# Altium schematic sheet Sheet1.SchDoc(Sheet1)
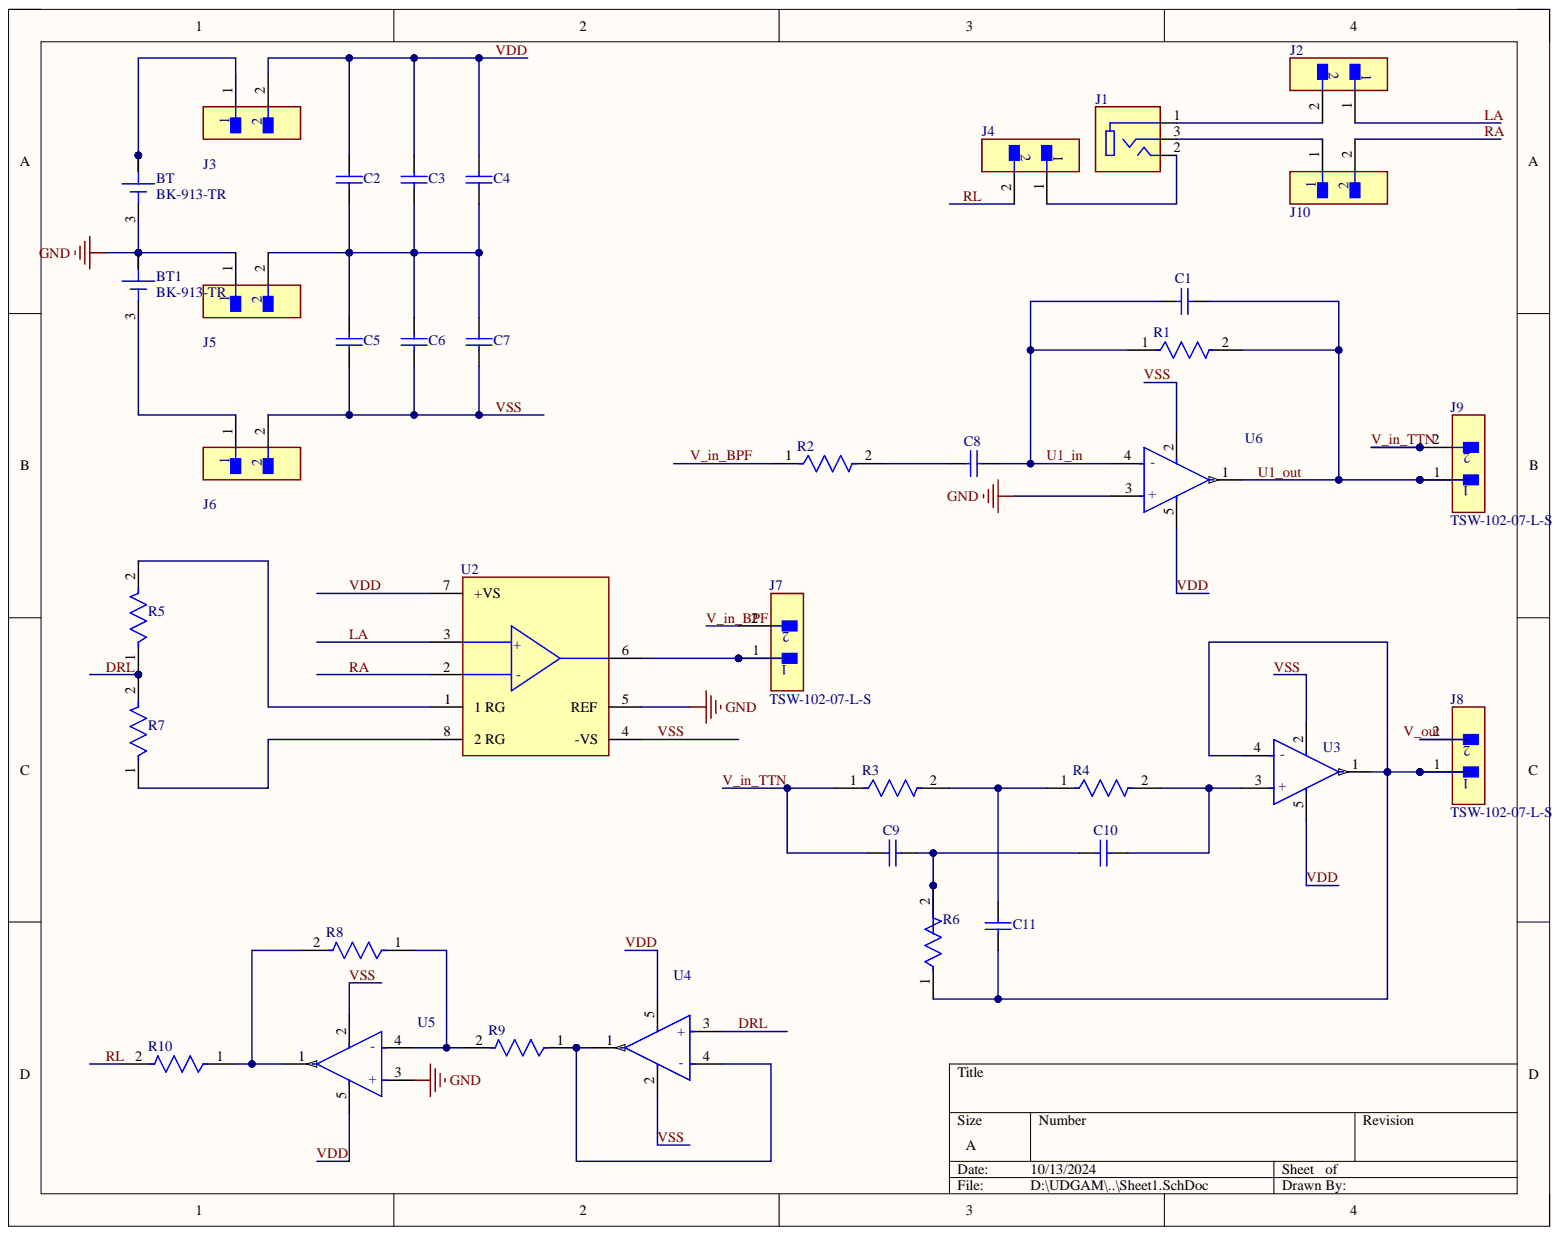
[diagram p0: Sheet1.SchDoc]

## Parts
BT  BK-913-TR  [BAT_BK-913-TR]
BT1  BK-913-TR  [BAT_BK-913-TR]
C1  C0805C225K9PACTU  [CAPC2013X145X50ML20T25]  (value not drawn)
C2  C0805C225K9PACTU  [CAPC2013X145X50ML20T25]  (value not drawn)
C3  C0805C225K9PACTU  [CAPC2013X145X50ML20T25]  (value not drawn)
C4  C0805C225K9PACTU  [CAPC2013X145X50ML20T25]  (value not drawn)
C5  C0805C225K9PACTU  [CAPC2013X145X50ML20T25]  (value not drawn)
C6  C0805C225K9PACTU  [CAPC2013X145X50ML20T25]  (value not drawn)
C7  C0805C225K9PACTU  [CAPC2013X145X50ML20T25]  (value not drawn)
C8  C0805C225K9PACTU  [CAPC2013X145X50ML20T25]  (value not drawn)
C9  C0805C225K9PACTU  [CAPC2013X145X50ML20T25]  (value not drawn)
C10  C0805C225K9PACTU  [CAPC2013X145X50ML20T25]  (value not drawn)
C11  C0805C225K9PACTU  [CAPC2013X145X50ML20T25]  (value not drawn)
J1  SJ-3523-SMT-TR  [CUI_SJ-3523-SMT-TR]  (value not drawn)
J2  TSW-102-07-L-S  [FP-TSW-102-07-L-S-MFG]
J3  TSW-102-07-L-S  [FP-TSW-102-07-L-S-MFG]
J4  TSW-102-07-L-S  [FP-TSW-102-07-L-S-MFG]
J5  TSW-102-07-L-S  [FP-TSW-102-07-L-S-MFG]
J6  TSW-102-07-L-S  [FP-TSW-102-07-L-S-MFG]
J7  TSW-102-07-L-S  [FP-TSW-102-07-L-S-MFG]
J8  TSW-102-07-L-S  [FP-TSW-102-07-L-S-MFG]
J9  TSW-102-07-L-S  [FP-TSW-102-07-L-S-MFG]
J10  TSW-102-07-L-S  [FP-TSW-102-07-L-S-MFG]
R1  CRCW080516K0FKEA  [FP-CRCW0805-e3-IPC_C]  (value not drawn)
R2  CRCW080516K0FKEA  [FP-CRCW0805-e3-IPC_C]  (value not drawn)
R3  CRCW080516K0FKEA  [FP-CRCW0805-e3-IPC_C]  (value not drawn)
R4  CRCW080516K0FKEA  [FP-CRCW0805-e3-IPC_C]  (value not drawn)
R5  CRCW080516K0FKEA  [FP-CRCW0805-e3-IPC_C]  (value not drawn)
R6  CRCW080516K0FKEA  [FP-CRCW0805-e3-IPC_C]  (value not drawn)
R7  CRCW080516K0FKEA  [FP-CRCW0805-e3-IPC_C]  (value not drawn)
R8  CRCW080516K0FKEA  [FP-CRCW0805-e3-IPC_C]  (value not drawn)
R9  CRCW080516K0FKEA  [FP-CRCW0805-e3-IPC_C]  (value not drawn)
R10  CRCW080516K0FKEA  [FP-CRCW0805-e3-IPC_C]  (value not drawn)
U2  AD620ARZ-REEL7  [FP-R-8-IPC_B]  (value not drawn)
U3  TLV521DCKT  [FP-DCK0005A-MFG]  (value not drawn)
U4  TLV521DCKT  [FP-DCK0005A-MFG]  (value not drawn)
U5  TLV521DCKT  [FP-DCK0005A-MFG]  (value not drawn)
U6  TLV521DCKT  [FP-DCK0005A-MFG]  (value not drawn)

## Nets
GND: BT.3 BT1.1 BT1.2 J5.1 U2.5(REF) U5.3(+) U6.3(+)
DRL: R5.1 R7.2 U4.3(+)
LA: J2.1 U2.3
RA: J10.2 U2.2
RL: J4.2 R10.2
U1_in: C1.2 C8.1 R1.1 U6.4(-)
U1_out: C1.1 J9.1 R1.2 U6.1
V_in_BPF: J7.2 R2.1
V_in_TTN: C9.2 J9.2 R3.1
VDD: C2.2 C3.2 C4.2 J3.2 U2.7(+VS) U3.5 U4.5 U5.5 U6.5
VSS: C5.1 C6.1 C7.1 J6.2 U2.4(-VS) U3.2 U4.2 U5.2 U6.2
NetBT1_3: BT1.3 J6.1
NetBT_1: BT.1 BT.2 J3.1
NetC2_1: C2.1 C3.1 C4.1 C5.2 C6.2 C7.2 J5.2
NetC8_2: C8.2 R2.2
NetC9_1: C9.1 C10.2 R6.2
NetC10_1: C10.1 R4.2 U3.3(+)
NetC11_1: C11.1 R3.2 R4.1
NetC11_2: C11.2 J8.1 R6.1 U3.1 U3.4(-)
NetJ1_1: J1.1 J2.2
NetJ1_2: J1.2 J4.1
NetJ1_3: J1.3 J10.1
NetJ7_1: J7.1 U2.6
NetR5_2: R5.2 U2.1(1_RG)
NetR7_1: R7.1 U2.8(2_RG)
NetR8_1: R8.1 R9.2 U5.4(-)
NetR8_2: R8.2 R10.1 U5.1
NetR9_1: R9.1 U4.1 U4.4(-)
V_out:

Not connected: J8 2

## Library data of the parts
BT BT1 = BK-913-TR: Battery Retainer Coin, 20.0mm 1 Cell PC Pin; MF: MPD (Memory; Component Kind: Standard; Digi-Key_Part_Number: BK-913-CT-ND; Library Name: SnapEDAsch.SchLib; MP: BK-913-TR; Package: MSOP-12 MPD; SnapEDA_Link: https://www.snapeda.com/parts/BK-913-TR/MPD%20(Memory%20Protection%20Devices)/view-part/576523/?ref=snap
C1-C11 = CMP-1036-04819-2: Manufacturer: Kemet; Component Kind: Standard; Library Name: Altium Content Vault; Part Number: C0805C225K9PACTU
J1 = SJ-3523-SMT-TR: <b>Audio Jack Connector</b> 3.5 mm Low Profile Surface Mount Stereo Jack, 3 Pin Right-Angle; Availability: Unavailable; Component Kind: Standard; Library Name: SJ-3523-SMT-TR.SchLib; MP: SJ-3523-SMT-TR; Package: None; Price: None
J2-J10 = CMP-02766-001428-1: CONN HEADER VERT 2POS 2.54MM; Connector Type: Male Pin; Current Rating: 7.6A; Gender: Male; Max Voltage Rating (AC): 550V; Number of Contacts: 2; Color: Black; Component Kind: Standard; Contact Material: Bronze; Contact Mating Length: 0.23inch; Contact Plating: Gold; Contact Type: Male Pin; Depth: 2.54mm; Housing Color: Black; Housing Material: Polyester; Lead Free: Lead Free; Length: 5.08mm; Library Name: Altium Content Vault; Max Current Rating: 7.6A; Max Operating Temperature: 125°C; Min Operating Temperature: -55°C; Mount: Through Hole; Number of Positions: 2; Number of Rows: 1; Orientation: Straight; Package Quantity: 1; Packaging: Bulk; Pitch: 2.54mm; Radiation Hardening: No; REACH SVHC: No SVHC; RoHS Compliant: Yes; Series: TSW; Termination: Solder; Voltage Rating: 550V; Width: 2.54mm
R1-R10 = CMP-2001-04979-2: RES Thick Film, 16kΩ, 1%, 0.125W, 100ppm/°C, 0805; Max Power Dissipation: 125mW; Resistance: 16kR; Voltage Rating: 150V; Case Code (Imperial): 0805; Case Code (Metric): 2012; Case/Package: 0805; Component Kind: Standard; Composition: Thick Film; Contact Plating: Tin; Depth: 1.25mm; Features: Automotive AEC-Q200; Height: 0.45mm; Lead Free: Lead Free; Length: 2mm; Library Name: Altium Content Vault; Max Operating Temperature: 155°C; Military Standard: Not; Min Operating Temperature: -55°C; Mount: Surface Mount; Number of Pins: 2; Number of Terminations: 2; Package Quantity: 10; Packaging: Tape and Reel; Power Rating: 125mW; Radiation Hardening: No; Temperature Coefficient: 100ppm/°C; Termination: SMD/SMT; Tolerance: 1%; Voltage Rating (DC): 150V; Weight: 0.000194oz; Width: 1.25mm
U2 = CMP-09116-000354-1: IC INST AMP 1 CIRCUIT 8SOIC; -3db Bandwidth: 1MHz; Bandwidth: 800kHz; Common Mode Rejection Ratio: 93dB; Dual Supply Voltage: 15V; Input Bias Current: 500pA; Input Offset Voltage (Vos): 30mV; Input Resistance: 10GR; Max Dual Supply Voltage: 18V; Max Power Dissipation: 650mW; Min Dual Supply Voltage: 2.3V; Min Supply Voltage: 4.6V; Nominal Supply Current: 900uA; Number of Channels: 1; Operating Supply Current: 1.3mA; Output Current per Channel: 18mA; Power Supply Rejection Ratio (PSRR): 140dB; Slew Rate: 1.2V/µs; Case/Package: SOIC; Component Kind: Standard; Contact Plating: Tin; Lead Free: Contains Lead; Library Name: Altium Content Vault; Max Operating Temperature: 125°C; Min Operating Temperature: -55°C; Mount: Surface Mount; Number of Circuits: 1; Number of Elements: 1; Number of Pins: 8; Operating Supply Voltage: 18V; Package Quantity: 1; Packaging: Tape and Reel; Power Dissipation: 650mW; Radiation Hardening: No; RoHS Compliant: Yes; Series: -; Supply Current: 900uA
U3-U6 = CMP-04895-000072-1: IC CMOS 1 CIRCUIT SC70-5; Bandwidth: 6kHz; Input Bias Current: 1pA; Input Offset Voltage (Vos): 100uV; Number of Amplifiers: 1; Operating Supply Current: 350nA; Output Current per Channel: 12mA; Slew Rate: 2.5V/µs; Case/Package: SC; Component Kind: Standard; Gain Bandwidth Product: 6kHz; Lead Free: Lead Free; Library Name: Altium Content Vault; Max Operating Temperature: 125°C; Min Operating Temperature: -40°C; Mount: Surface Mount; Number of Channels: 1; Number of Circuits: 1; Number of Pins: 5; Packaging: Cut Tape; REACH SVHC: No SVHC
